# Altium schematic sheet powersupply.SchDoc
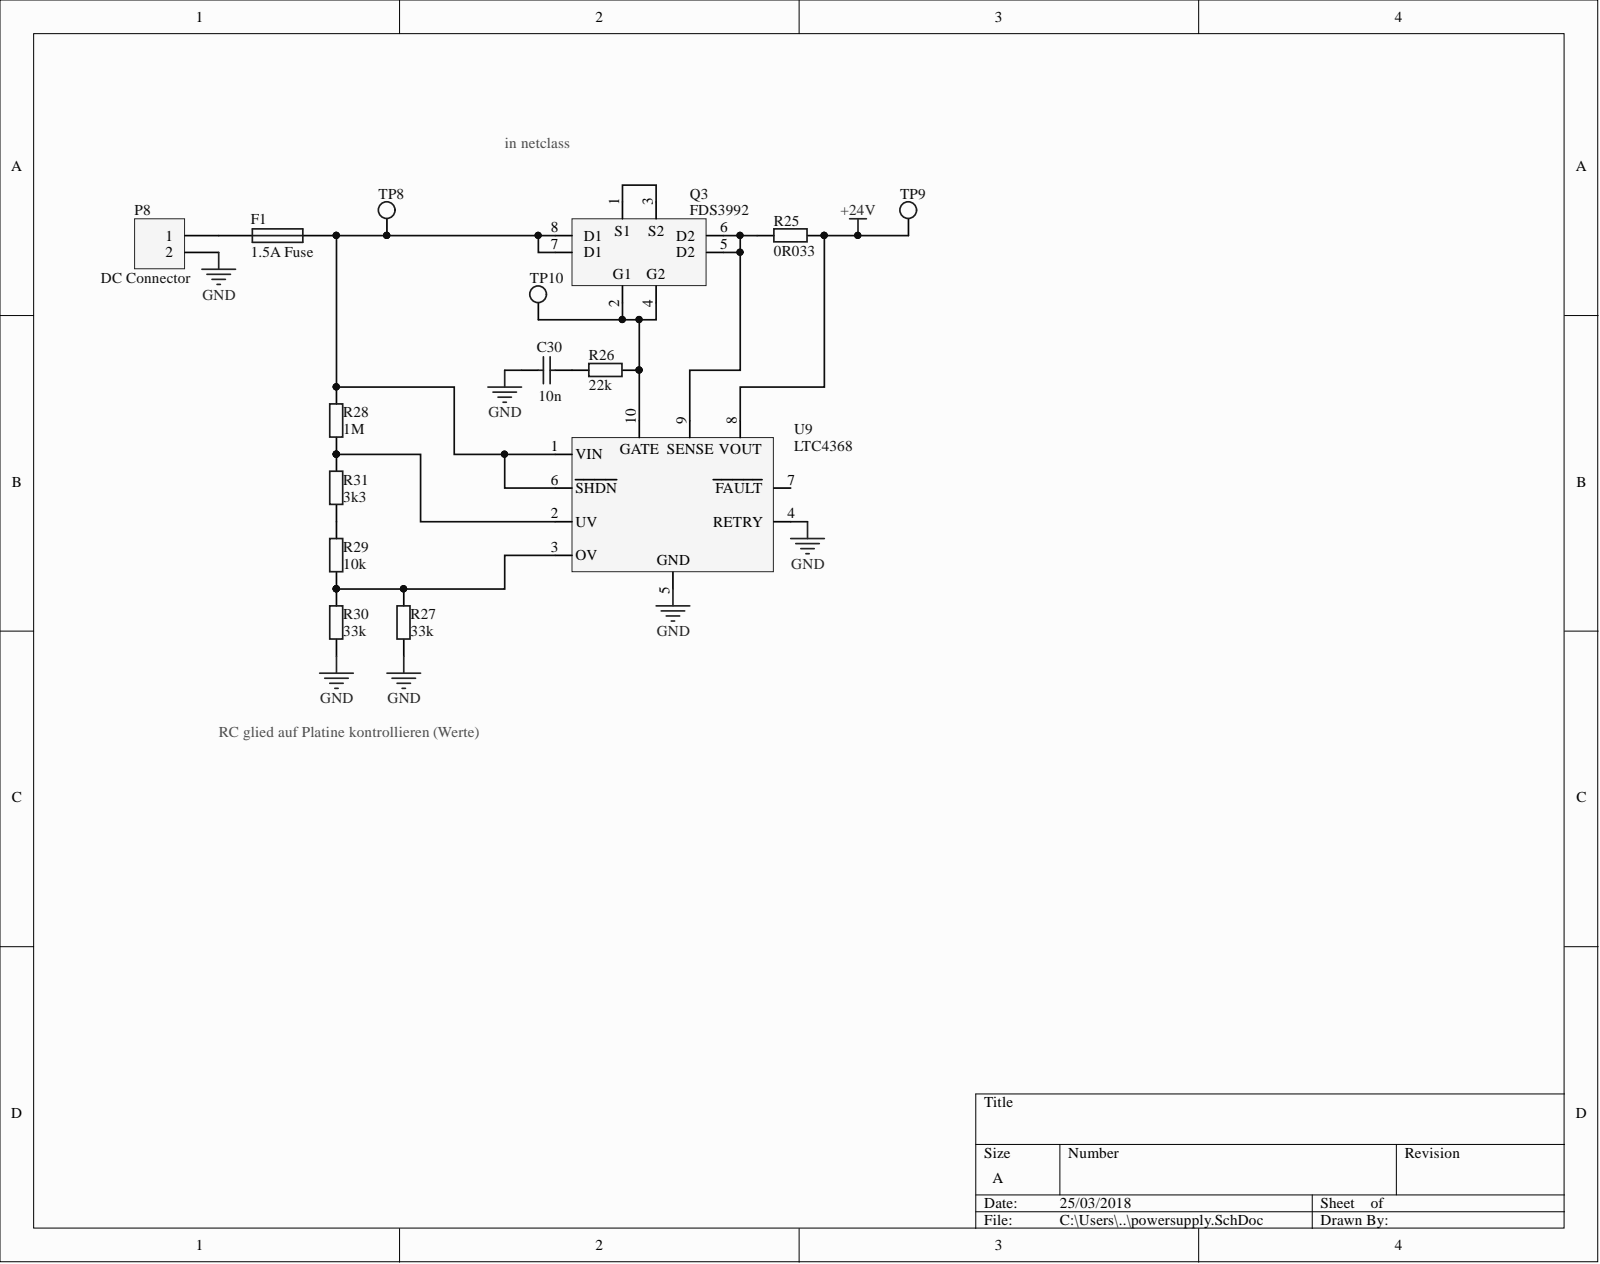
[diagram p0: powersupply.SchDoc]

## Parts
C30  value 10n  [6-0805_M]  (hidden comment: Cap)
F1  1.5A Fuse  [OMNI_BLOK_FUSE]
P8  DC Connector  [HDR1X2]
Q3  FDS3992  [SOIC-8]
R25  value 0R033  [C1206]  (hidden comment: Res2)
R26  value 22k  [6-0805_M]  (hidden comment: Res2)
R27  value 33k  [6-0805_M]  (hidden comment: Res2)
R28  value 1M  [6-0805_M]  (hidden comment: Res2)
R29  value 10k  [6-0805_M]  (hidden comment: Res2)
R30  value 33k  [6-0805_M]  (hidden comment: Res2)
R31  value 3k3  [6-0805_M]  (hidden comment: Res2)
TP8  TestPoint  [MEASUREMENT_POINT]  (value not drawn)
TP9  TestPoint  [MEASUREMENT_POINT]  (value not drawn)
TP10  TestPoint  [MEASUREMENT_POINT]  (value not drawn)
U9  LTC4368  [MSOP-10]

## Nets
+24V: R25.2 TP9.1 U9.8
GND: C30.1 P8.2 R27.1 R30.1 U9.4(RETRY) U9.5
NetC30_2: C30.2 R26.1
NetF1_1: F1.1 P8.1
NetF1_2: F1.2 Q3.7(D1) Q3.8(D1) R28.2 TP8.1 U9.1(VIN) U9.6(SHDN)
NetQ3_1: Q3.1(S1) Q3.3(S2)
NetQ3_2: Q3.2(G1) Q3.4(G2) R26.2 TP10.1 U9.10
NetQ3_5: Q3.5(D2) Q3.6(D2) R25.1 U9.9
NetR27_2: R27.2 R29.1 R30.2 U9.3(OV)
NetR28_1: R28.1 R31.2 U9.2(UV)
NetR29_2: R29.2 R31.1

Not connected: U9 7(FAULT)

## Library data of the parts
C30 = Cap: Capacitor; Component Kind: Standard; LatestRevisionDate: 17-Jul-2002; LatestRevisionNote: Re-released for DXP Platform.; Library Name: Miscellaneous Devices.IntLib; PackageDescription: Radial Cap, Thru-Hole; 2 Leads; 0.3 in Pin Spacing; PackageReference: RAD-0.3; Published: 8-Jun-2000; Signal Integrity: Cap; Simulation: CAP; Publisher: Altium Limited
F1 = Fuse 1: Fuse; Component Kind: Standard; LatestRevisionDate: 17-Jul-2002; LatestRevisionNote: Re-released for DXP Platform.; Library Name: Miscellaneous Devices.IntLib; PackageDescription: Thru-Hole; 2 Leads; PackageReference: PIN-W2/E2.8; Published: 8-Jun-2000; Simulation: FUSE; Publisher: Altium Limited
P8 = Header 2: Header, 2-Pin; Component Kind: Standard; LatestRevisionDate: 17-Jul-2002; LatestRevisionNote: Re-released for DXP Platform.; Library Name: Miscellaneous Connectors.IntLib; Signal Integrity: Connector; Publisher: Altium Limited
Q3 = FDS3992: FDS3992 Dual N-Channel MOSFET; Component Kind: Standard; Library Name: clusterlib.SchLib
R25-R31 = Res2: Resistor; Component Kind: Standard; LatestRevisionDate: 17-Jul-2002; LatestRevisionNote: Re-released for DXP Platform.; Library Name: Miscellaneous Devices.IntLib; PackageDescription: Axial Device, Thru-Hole; 2 Leads; 0.4 in Pin Spacing; PackageReference: AXIAL-0.4; Published: 8-Jun-2000; Signal Integrity: Res; Simulation: RESISTOR; Publisher: Altium Limited
U9 = LTC4368: LTC4368 UV/OV/Reverse Protection IC; Component Kind: Standard; Library Name: clusterlib.SchLib
TP8-TP10 = TestPoint: Component Kind: Standard; Description: Testpoint; Library Name: clusterlib.SchLib
